# Altium schematic sheet STM32F4.SchDoc
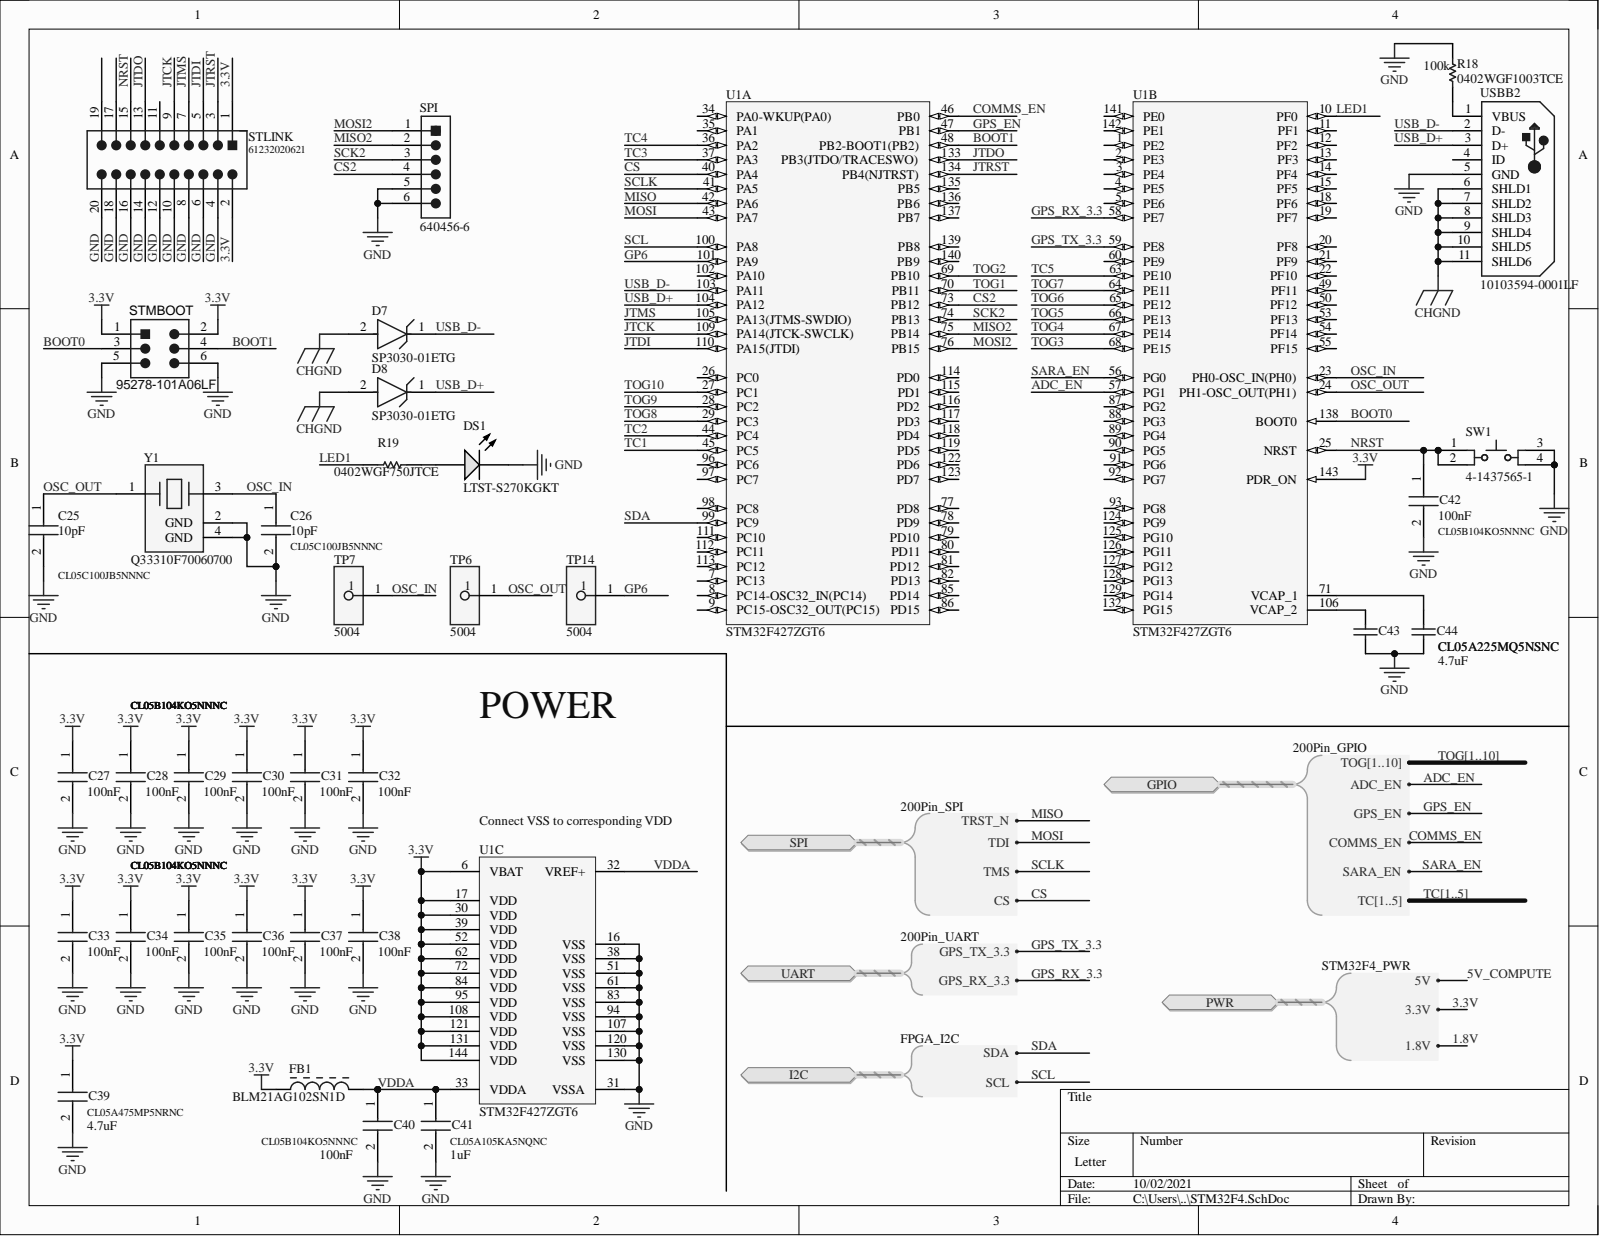
[diagram p0: STM32F4.SchDoc]

## Parts
C25  CL05C100JB5NNNC  value 10pF  [CAPC1005X55N]
C26  CL05C100JB5NNNC  value 10pF  [CAPC1005X55N]
C27  CL05B104KO5NNNC  value 100nF  [CAPC1005X55N]
C28  CL05B104KO5NNNC  value 100nF  [CAPC1005X55N]
C29  CL05B104KO5NNNC  value 100nF  [CAPC1005X55N]
C30  CL05B104KO5NNNC  value 100nF  [CAPC1005X55N]
C31  CL05B104KO5NNNC  value 100nF  [CAPC1005X55N]
C32  CL05B104KO5NNNC  value 100nF  [CAPC1005X55N]
C33  CL05B104KO5NNNC  value 100nF  [CAPC1005X55N]
C34  CL05B104KO5NNNC  value 100nF  [CAPC1005X55N]
C35  CL05B104KO5NNNC  value 100nF  [CAPC1005X55N]
C36  CL05B104KO5NNNC  value 100nF  [CAPC1005X55N]
C37  CL05B104KO5NNNC  value 100nF  [CAPC1005X55N]
C38  CL05B104KO5NNNC  value 100nF  [CAPC1005X55N]
C39  CL05A475MP5NRNC  value 4.7uF  [CAPC1005X55N]
C40  CL05B104KO5NNNC  value 100nF  [CAPC1005X55N]
C41  CL05A105KA5NQNC  value 1uF  [CAPC1005X55N]
C42  CL05B104KO5NNNC  value 100nF  [CAPC1005X55N]
C43  CL05A225MQ5NSNC  [0402]
C44  CL05A225MQ5NSNC  [0402]
D7  SP3030-01ETG  [FP-SP3030-MFG]
D8  SP3030-01ETG  [FP-SP3030-MFG]
DS1  LTST-S270KGKT  [AVAG-HSMX-C190_V]
FB1  BLM21AG102SN1D  [MURA-BLM21-H1-CHIP-2_V]
R18  0402WGF1003TCE  [0402]
R19  0402WGF750JTCE  [RESC1005X40X25ML05T10]
SPI  640456-6  [TECO-640456-6_V]
STLINK  61232020621  [61232020621]
STMBOOT  95278-101A06LF  [AMPM-95278-101A06LF_V]
SW1  4-1437565-1  [TECO-4-1437565-1_V]
TP6  5004  [FP-5004-MFG]
TP7  5004  [FP-5004-MFG]
TP14  5004  [FP-5004-MFG]
U1  STM32F427ZGT6  [STM-LQFP144_V]  (parts A,B,C)
USBB2  10103594-0001LF  [FCI-10103594-0001LF_V]
Y1  Q33310F70060700  [FP-7M-MFG]

## Ports
GPIO, GPIO.ADC_EN, GPIO.COMMS_EN, GPIO.GPS_EN, GPIO.SARA_EN, GPIO.TC1, GPIO.TC2, GPIO.TC3, GPIO.TC4, GPIO.TC5, GPIO.TC[1..5], GPIO.TOG1, GPIO.TOG2, GPIO.TOG3, GPIO.TOG4, GPIO.TOG5, GPIO.TOG6, GPIO.TOG7, GPIO.TOG8, GPIO.TOG9, GPIO.TOG10, GPIO.TOG[1..10], I2C, I2C.SCL, I2C.SDA, PWR, PWR.1.8V, PWR.3.3V, PWR.5V, SPI, SPI.CS, SPI.TDI, SPI.TMS, SPI.TRST_N, UART, UART.GPS_RX_3.3, UART.GPS_TX_3.3

## Nets
CHGND: D7.2 D8.2 USBB2.6(SHLD1) USBB2.7(SHLD2) USBB2.8(SHLD3) USBB2.9(SHLD4) USBB2.10(SHLD5) USBB2.11(SHLD6)
3.3V: C27.1 C28.1 C29.1 C30.1 C31.1 C32.1 C33.1 C34.1 C35.1 C36.1 C37.1 C38.1 C39.1 FB1.1 STLINK.1 STLINK.2 STMBOOT.1 STMBOOT.2 U1.6(VBAT) U1.17(VDD) U1.30(VDD) U1.39(VDD) U1.52(VDD) U1.62(VDD) U1.72(VDD) U1.84(VDD) U1.95(VDD) U1.108(VDD) U1.121(VDD) U1.131(VDD) U1.143(PDR_ON) U1.144(VDD) ; port PWR
ADC_EN: U1.57(PG1) ; port GPIO
BOOT0: STMBOOT.3 U1.138(BOOT0)
BOOT1: STMBOOT.4 U1.48(PB2-BOOT1(PB2))
COMMS_EN: U1.46(PB0) ; port GPIO
CS: U1.40(PA4) ; port SPI
CS2: SPI.4 U1.73(PB12)
GND: C25.2 C26.2 C27.2 C28.2 C29.2 C30.2 C31.2 C32.2 C33.2 C34.2 C35.2 C36.2 C37.2 C38.2 C39.2 C40.2 C41.2 C42.2 C43.1 C44.1 DS1.2 R18.2 SPI.5 SPI.6 STLINK.4 STLINK.6 STLINK.8 STLINK.10 STLINK.12 STLINK.14 STLINK.16 STLINK.18 STLINK.20 STMBOOT.5 STMBOOT.6 SW1.3 SW1.4 U1.16(VSS) U1.31(VSSA) U1.38(VSS) U1.51(VSS) U1.61(VSS) U1.83(VSS) U1.94(VSS) U1.107(VSS) U1.120(VSS) U1.130(VSS) USBB2.5(GND) Y1.2(GND) Y1.4(GND)
GP6: TP14.1 U1.101(PA9)
GPS_EN: U1.47(PB1) ; port GPIO
GPS_RX_3.3: U1.58(PE7) ; port UART
GPS_TX_3.3: U1.59(PE8) ; port UART
JTCK: STLINK.9 U1.109(PA14(JTCK-SWCLK))
JTDI: STLINK.5 U1.110(PA15(JTDI))
JTDO: STLINK.13 U1.133(PB3(JTDO/TRACESWO))
JTMS: STLINK.7 U1.105(PA13(JTMS-SWDIO))
JTRST: STLINK.3 U1.134(PB4(NJTRST))
LED1: R19.2 U1.10(PF0)
MISO: U1.42(PA6) ; port SPI
MISO2: SPI.2 U1.75(PB14)
MOSI: U1.43(PA7) ; port SPI
MOSI2: SPI.1 U1.76(PB15)
NRST: C42.1 STLINK.15 SW1.1 SW1.2 U1.25(NRST)
OSC_IN: C26.1 TP7.1 U1.23(PH0-OSC_IN(PH0)) Y1.3
OSC_OUT: C25.1 TP6.1 U1.24(PH1-OSC_OUT(PH1)) Y1.1
SARA_EN: U1.56(PG0) ; port GPIO
SCK2: SPI.3 U1.74(PB13)
SCL: U1.100(PA8) ; port I2C
SCLK: U1.41(PA5) ; port SPI
SDA: U1.99(PC9) ; port I2C
TC1: U1.45(PC5) ; port GPIO  [also labelled TC]
TC2: U1.44(PC4) ; port GPIO  [also labelled TC]
TC3: U1.37(PA3) ; port GPIO  [also labelled TC]
TC4: U1.36(PA2) ; port GPIO  [also labelled TC]
TC5: U1.63(PE10) ; port GPIO  [also labelled TC]
TOG1: U1.70(PB11) ; port GPIO  [also labelled TOG]
TOG2: U1.69(PB10) ; port GPIO  [also labelled TOG]
TOG3: U1.68(PE15) ; port GPIO  [also labelled TOG]
TOG4: U1.67(PE14) ; port GPIO  [also labelled TOG]
TOG5: U1.66(PE13) ; port GPIO  [also labelled TOG]
TOG6: U1.65(PE12) ; port GPIO  [also labelled TOG]
TOG7: U1.64(PE11) ; port GPIO  [also labelled TOG]
TOG8: U1.29(PC3) ; port GPIO  [also labelled TOG]
TOG9: U1.28(PC2) ; port GPIO  [also labelled TOG]
TOG10: U1.27(PC1) ; port GPIO  [also labelled TOG]
USB_D+: D8.1 U1.104(PA12) USBB2.3(D+)
USB_D-: D7.1 U1.103(PA11) USBB2.2(D-)
VDDA: C40.1 C41.1 FB1.2 U1.32(VREF+) U1.33(VDDA)
NetC43_2: C43.2 U1.106(VCAP_2)
NetC44_2: C44.2 U1.71(VCAP_1)
NetDS1_1: DS1.1 R19.1
NetR18_1: R18.1 USBB2.1(VBUS)
NetU1_1: U1.1(PE2)
NetU1_2: U1.2(PE3)
NetU1_3: U1.3(PE4)
NetU1_4: U1.4(PE5)
NetU1_5: U1.5(PE6)
NetU1_7: U1.7(PC13)
NetU1_8: U1.8(PC14-OSC32_IN(PC14))
NetU1_14: U1.14(PF4)
NetU1_15: U1.15(PF5)
NetU1_18: U1.18(PF6)
NetU1_19: U1.19(PF7)
NetU1_20: U1.20(PF8)
NetU1_21: U1.21(PF9)
NetU1_26: U1.26(PC0)
NetU1_34: U1.34(PA0-WKUP(PA0))
NetU1_35: U1.35(PA1)
NetU1_49: U1.49(PF11)
NetU1_50: U1.50(PF12)
NetU1_53: U1.53(PF13)
NetU1_54: U1.54(PF14)
NetU1_55: U1.55(PF15)
NetU1_60: U1.60(PE9)
NetU1_77: U1.77(PD8)
NetU1_78: U1.78(PD9)
NetU1_87: U1.87(PG2)
NetU1_96: U1.96(PC6)
NetU1_97: U1.97(PC7)
1.8V: ; port PWR
5V_COMPUTE: ; port PWR

Not connected: STLINK 11 17 19; U1 9(PC15-OSC32_OUT(PC15)) 11(PF1) 12(PF2) 13(PF3) 22(PF10) 79(PD10) 80(PD11) 81(PD12) 82(PD13) 85(PD14) 86(PD15) 88(PG3) 89(PG4) 90(PG5) 91(PG6) 92(PG7) 93(PG8) 98(PC8) 102(PA10) 111(PC10) 112(PC11) 113(PC12) 114(PD0) 115(PD1) 116(PD2) 117(PD3) 118(PD4) 119(PD5) 122(PD6) 123(PD7) 124(PG9) 125(PG10) 126(PG11) 127(PG12) 128(PG13) 129(PG14) 132(PG15) 135(PB5) 136(PB6) 137(PB7) 139(PB8) 140(PB9) 141(PE0) 142(PE1); USBB2 4(ID)

## Library data of the parts
C25-C42 = C0402C560K5RACTU: 100nF 0402; Manufacturer_Name: Kemet; Arrow Part Number: C0402C560K5RACTU; Component Kind: Standard; Datasheet Link: https://content.kemet.com/datasheets/KEM_C1002_X7R_SMD.pdf; Height: 0.55mm; Manufacturer_Part_Number: C0402C560K5RACTU; Mouser Part Number: 80-C0402C560K5RACTU; Mouser Price/Stock: https://www.mouser.co.uk/ProductDetail/KEMET/C0402C560K5RACTU?qs=gt1LBUVyoHmQZW5v3ySgNQ%3D%3D
C43 C44 = Cap: 2.2uF 0402; Component Kind: Standard; LatestRevisionDate: 17-Jul-2002; LatestRevisionNote: Re-released for DXP Platform.; Library Name: Miscellaneous Devices.IntLib; PackageDescription: Radial Cap, Thru-Hole; 2 Leads; 0.3 in Pin Spacing; PackageReference: RAD-0.3; Published: 8-Jun-2000; Signal Integrity: Cap; Simulation: CAP; Publisher: Altium Limited
D7 D8 = CMP-08607-000070-1: TVS DIODE 5V 10V SOD882; Manufacturer: Littelfuse; Automotive Grade: Grade 1; Capacitance: 0.5pF; Direction: Unidirectional; Max Junction Temp: +150°C; Number Of Channels: 1; Peak Pulse Current: 3A; Value: 0.5pF; Automotive: Yes; Category: Diode; Clamping Voltage: 10V; Component Kind: Standard; ComponentLink2Description: Datasheet; ComponentLink2URL: https://www.littelfuse.com/~/media/electronics/datasheets/tvs_diode_arrays/littelfuse_tvs_diode_array_sp3030_datasheet.pdf.pdf; Device Class L1: Circuit Protection; Device Class L2: TVS Diodes; Device Class L3: unset; Digikey Description: TVS DIODE 5V 10V SOD882; Height: 0.6mm; Lead Free: Yes; Library Name: Altium Content Vault; Manufacturer Part Number: SP3030-01ETG; Mouser Description: TVS Diodes / ESD Suppressors 5V 1uA 22kV UNIDIRECTIONAL; Octopart: https://octopart.com/sp3030-01etg-littelfuse-29687287; Package: SP3030; Reverse Standoff Voltage: 5V; RoHS: TRUE; Temperature: -40°C to +125°C; Temperature Range High: +125°C; Temperature Range Low: -40°C
DS1 = CMP-1744-00003-1: LED Uni-Color Yellow, 65 mW, 20 mA, -40 to 85 degC, 2-Pin SMD, RoHS, Tape and Reel; Case\Package: 0603; Power Rating: 100 mW; Resistance: 390 Ohm; Tolerance: 1%; Voltage Rating: 50 V; Case Code (Imperial): 0603; Case Code (Metric): 1608; Component Kind: Standard; ComponentLink1Description: Manufacturer URL; ComponentLink1URL: http://www.avagotech.com/; ComponentLink2Description: Datasheet; ComponentLink2URL: http://docs.avagotech.com/docs/AV02-0551EN; ComponentLink3Description: Package Specification; ComponentLink3URL: http://www.fairchildsemi.com/dwg/MA/MA03D.pdf; DatasheetVersion: Mar-12; Height: 0.45 mm; Length: 1.6 mm; Library Name: Altium Content Vault; Max Operating Temperature: 155 degC; Min Operating Temperature: -55 degC; Mount: Surface Mount; Package Quantity: 5000; PackageDescription: 2-Pin Surface Mount Device, Body 1.6 x 0.8 mm, Height 0.9 mm; PackageReference: HSMX-C190; Packaging: Tape and Reel; Pins: 2; REACH SVHC: No SVHC; RoHSCompliant: true; Voltage Rating (DC): 50 V; Width: 0.8 mm
FB1 = CMP-0686-00665-2: Chip Ferrite Bead for General Signal lines, 1000 Ohm, 500 mA, -55 to 125 degC, 2 x 1.25 x 1.05 mm SMD, Tape and Reel; Manufacturer: Murata; DC Resistance: 0.28 Ohm Max.; Impedance (at 100 MHz): 1000 Ohm +/- 25%; Rated Current: 500 mA; Component Kind: Standard; ComponentLink1Description: Manufacturer URL; ComponentLink1URL: http://www.murata.com/; ComponentLink2Description: Datasheet; ComponentLink2URL: http://www.murata.com/products/catalog/pdf/c31e.pdf; ComponentLink3Description: Package Specification; ComponentLink3URL: http://www.murata.com/products/catalog/pdf/c31e.pdf; DatasheetVersion: 08/2013; Library Name: Altium Content Vault; Mounting Technology: Surface Mount; Operating Temperature Range: -55 to 125 degC; PackageDescription: 2-Pin Chip, Body 2 x 1.25 mm; PackageReference: BLM21_H1050-2; Packing: Tape and Reel; PartNumber: BLM21AG102SN1D
R18 = Res1: 100k 0402; Component Kind: Standard; LatestRevisionDate: 17-Jul-2002; LatestRevisionNote: Re-released for DXP Platform.; Library Name: Miscellaneous Devices.IntLib; PackageDescription: Axial Device, Thru-Hole; 2 Leads; 0.3 in Pin Spacing; PackageReference: AXIAL-0.3; Published: 8-Jun-2000; Signal Integrity: Res; Simulation: RESISTOR; Publisher: Altium Limited
R19 = CMP-2002-07344-1: 75R 0402; Manufacturer: Yageo / Phycomp; Part Number: RC0402FR-07100RL; Component Kind: Standard; Library Name: Altium Content Vault
SPI = CMP-2000-05661-1: Male Header, Pitch 2.54 mm, 1 x 6 Position, Height 10.03 mm, Tail Length 3.56 mm, -55 to 105 degC, Bulk; Manufacturer: TE Connectivity; Agency Approvals: CSA,UL; Angle: Straight; Brand/Series: MTA-100Series; Color, Housing: White; Connector Type: MTA-100; Contact Centerline Spacing: 0.100    in.; Contact Termination Area Plating: Tin; Current, Rating: 5    A; Material, Contact: CopperAlloy; Material, Housing: Polyester; Mount Angle: Vertical; Mounting Hole Size: 0.04    "; Number of Contacts: 6; Pin Spacing: 0.1    "; Primary Type: InterconnectSystem; Special Features: Pinstrip; Standards: RoHSCompliant,ELVCompliant,UL94V-0; Temperature, Operating: -55to+105    °C; Voltage, Rating: 250    V; Color: White; Component Kind: Standard; Contact Mating Area Plating: Tin; Contact Plating: Tin; Contact Shape: Square; Datasheet URL: https://datasheet.ciiva.com/25618/0900766b80eb7fdd-25618163.pdf; Datasheet Version: Rev. W, 05/2005; Flammability Rating: UL94V-0; Length, Overall: 0.6    "; Length, Pin: 0.14    "; Length, Terminal Post: 0.14    in.; Library Name: Altium Content Vault; Manufacturer URL: http://www.te.com/; Mounting Technology: ThroughHole; Mounting Type: PCMount; Number of Positions: 6; Number of Rows: 1; Package Description: Male Header, Pitch 2.54 mm, 1 x 6 Position, Height 10.03 mm, Tail Length 3.56 mm; Package Reference: 640456-6; Size, Post: 0.025    in.; Termination: Solder; Type: PCBPlug
STLINK = CMP-1502-00063-1: Male Box Header WR-BHD, SMT, Vertical, pitch 2.54 mm, 20 pins; Manufacturer: Wurth Elektronik; Contact Resistance: 20mOhm; Pin Number: 20; Pitch: 2.54mm; Withstanding Voltage: 500VAC; Working Voltage: 250VAC; Component Kind: Standard; Datasheet URL: https://katalog.we-online.de/em/datasheet/6123xx20621.pdf; Gender: Male; Library Name: Altium Content Vault; Manufacturer URL: http://www.we-online.de; Mounting Technology: SMT; Operating Temperature: -40to125degC; Orientation: Vertical; Package Reference: 61232020621; Packaging: TapeandReel; Part Number: 61232020621; Published Date: August2018; Publisher: WurthElektronik
STMBOOT = CMP-1743-00002-1: Male Header, Pitch 2.54 mm, 2 x 3 Position, Height 14.4 mm, RoHS, Tape and Reel; Case\Package: 0603; Power Rating: 100 mW; Resistance: 49.9 Ohm; Tolerance: 1%; Voltage Rating: 50 V; Case Code (Imperial): 0603; Case Code (Metric): 1608; Component Kind: Standard; ComponentLink1Description: Datasheet; ComponentLink1URL: http://portal.fciconnect.com/Comergent//fci/drawing/95278.pdf; DatasheetVersion: Rev. AC, 03/2016; Height: 0.45 mm; Length: 1.6 mm; Library Name: Altium Content Vault; Max Operating Temperature: 155 degC; Min Operating Temperature: -55 degC; Mount: Surface Mount; PackageDescription: Male Header, Pitch 2.54 mm, 2 x 3 Position, Height 14.4 mm; PackageReference: 95278-101A06LF; Packaging: Tape and Reel; REACH SVHC: No SVHC; RoHSCompliant: true; Width: 0.8 mm
SW1 = CMP-2000-05602-1: Tactile Switch, 50 mA, 12 VDC, -20 to 85 degC, 4-Pin SMD, RoHS, Bulk; Manufacturer: TEConnectivity/AMPBrand; Actuator Length: 1.4mm; Actuator Style: RoundButton; Body Orientation: Straight; Contact Rating @ Voltage: 0.05@12VDCA; Dielectric Strength: 500VAC; Electrical Life: 100000Cycle; Ground Terminal: No; Illumination Type: N/R; Maximum Contact Resistance: 100mOhm; Maximum DC Voltage Rating: 12V; Operating Force: 1.57N; Actuator Color: N/A; Base Material: N/A; Component Kind: Standard; Contact Material: CopperAlloy; Contact Plating: Silver; Datasheet URL: https://datasheet.ciiva.com/14635/0900766b80912216-14635826.pdf; Datasheet Version: 04/2007; Dimension: 6x10x5.25mm; Family: FSMJSMA; Housing Material: N/A; Library Name: Altium Content Vault; Manufacturer URL: http://www.te.com/; Maximum AC Voltage Rating: N/A; Mechanical Life: N/A; Minimum Insulation Resistance: 100MOhm; Mounting: SurfaceMount,GullWing; Mounting Technology: SurfaceMount; Operating Temperature: -20to85C; Package Description: 4-Pin Surface Mount Device, Body 6 x 6 mm, Pitch 9.1 mm; Package Reference: 4-1437565-1; Packaging: Bulk; Part No: 4-1437565-1; Pole Throw Configuration: N/A; Product Weight: N/A; RoHS: Yes; Seal Description: N/A; Seal Location: N/A; Switch Configuration: N/A; Type: N/A
TP6 TP7 TP14 = CMP-2000-05575-2: PC TEST POINT MINIATURE YELLOW; Manufacturer: Keystone; Is Female: yes; Number Of Contacts: 1; Automotive: No; Category: Conn; Component Kind: Standard; ComponentLink2Description: Datasheet; ComponentLink2URL: https://www.keyelco.com/product-pdf.cfm?p=1313; Contact Material: Silver; Device Class L1: Connectors; Device Class L2: unset; Device Class L3: unset; Digikey Description: PC TEST POINT MINIATURE YELLOW; Height: 4.72mm; Lead Free: Yes; Library Name: Altium Content Vault; Manufacturer Part Number: 5004; Mouser Description: TEST POINT PC MINI .040"D YELLOW; Octopart: https://octopart.com/5004-keystone-28591; Package: 5004; RoHS: TRUE
U1 = CMP-0243-00054-2: ARM Cortex-M4 32-bit MCU+FPU, 210 DMIPS, 1024 kB Flash, 256 kB Internal RAM, 114 I/Os, 144-pin LQFP, -40 to 85 degC, Tray; Manufacturer: STMicroelectronics; D/A Converter: 2x12-bit; FLASH Size (Prog) (kB): 1024; I/Os (High Current): 114; Internal RAM Size (kB): 256; Operating Frequency(F) (Processor speed) (MHz): 168; Supply Voltage(Vcc) max (V): 3.6; Supply Voltage(Vcc) min (V): 1.8; Component Kind: Standard; ComponentLink1Description: Manufacturer URL; ComponentLink1URL: http://www.st.com/web/en/home.html; ComponentLink2Description: Datasheet; ComponentLink2URL: http://www.st.com/st-web-ui/static/active/en/resource/technical/document/datasheet/DM00071990.pdf; ComponentLink3Description: Package Specification; ComponentLink3URL: http://www.st.com/st-web-ui/static/active/en/resource/technical/document/datasheet/DM00037051.pdf; Core: ARM Cortex-M4; DatasheetVersion: Rev. 1; Library Name: Altium Content Vault; Mounting Technology: Surface Mount; PackageDescription: 144-Pin Low Profile Quad Flat Package, 20 x 20 mm Body, 0.5 mm Pitch; PackageReference: LQFP144; PackageVersion: Rev. 4, 06/2013; Packing: Tray; PartNumber: STM32F427ZGT6
USBB2 = CMP-1698-00007-1: Micro USB 2.0 B Receptacle, -55 to 85 degC, 5-Pin SMD, RoHS, Tape and Reel; Case\Package: 0603; Power Rating: 100 mW; Resistance: 4.7 kohm; Tolerance: 1%; Type (Resistor): Thick Film; Voltage Rating: 75 V; Case Code (Imperial): 0603; Case Code (Metric): 1608; Component Kind: Standard; ComponentLink1Description: Manufacturer URL; ComponentLink1URL: http://portal.fciconnect.com/; ComponentLink2Description: Datasheet; ComponentLink2URL: http://portal.fciconnect.com/Comergent//fci/drawing/10103594.pdf; DatasheetVersion: Rev. A, 06/2010; Height: 0.45 mm; Length: 1.55 mm; Library Name: Altium Content Vault; Max Operating Temperature: 155 degC; Min Operating Temperature: -55 degC; Mount: Surface Mount; Package Quantity: 5000; PackageDescription: 5-Pin Surface Mount Device, Body 8.04 x 5.62 mm; PackageReference: FCI-10103594-0001LF; Packaging: Tape and Reel; Pins: 2; REACH SVHC: Unknown; RoHSCompliant: true; Weight: 7.1E-05 oz; Width: 0.85 mm
Y1 = CMP-18717-000014-1: CRYSTAL 12.0000MHZ 15PF SMD; ESR (Equivalent Series Resistance): 60R; Frequency: 32MHz; Frequency Stability: 30ppm; Load Capacitance: 12pF; Case/Package: SMD/SMT; Component Kind: Standard; Frequency Tolerance: 30ppm; Height: 0.031inch; Lead Free: Lead Free; Length: 0.126inch; Library Name: Altium Content Vault; Max Operating Temperature: 85°C; Min Operating Temperature: -40°C; Mount: Surface Mount; Package Quantity: 1; Packaging: Tape and Reel; Width: 0.098inch
